# Altium schematic sheet Sheet1.SchDoc
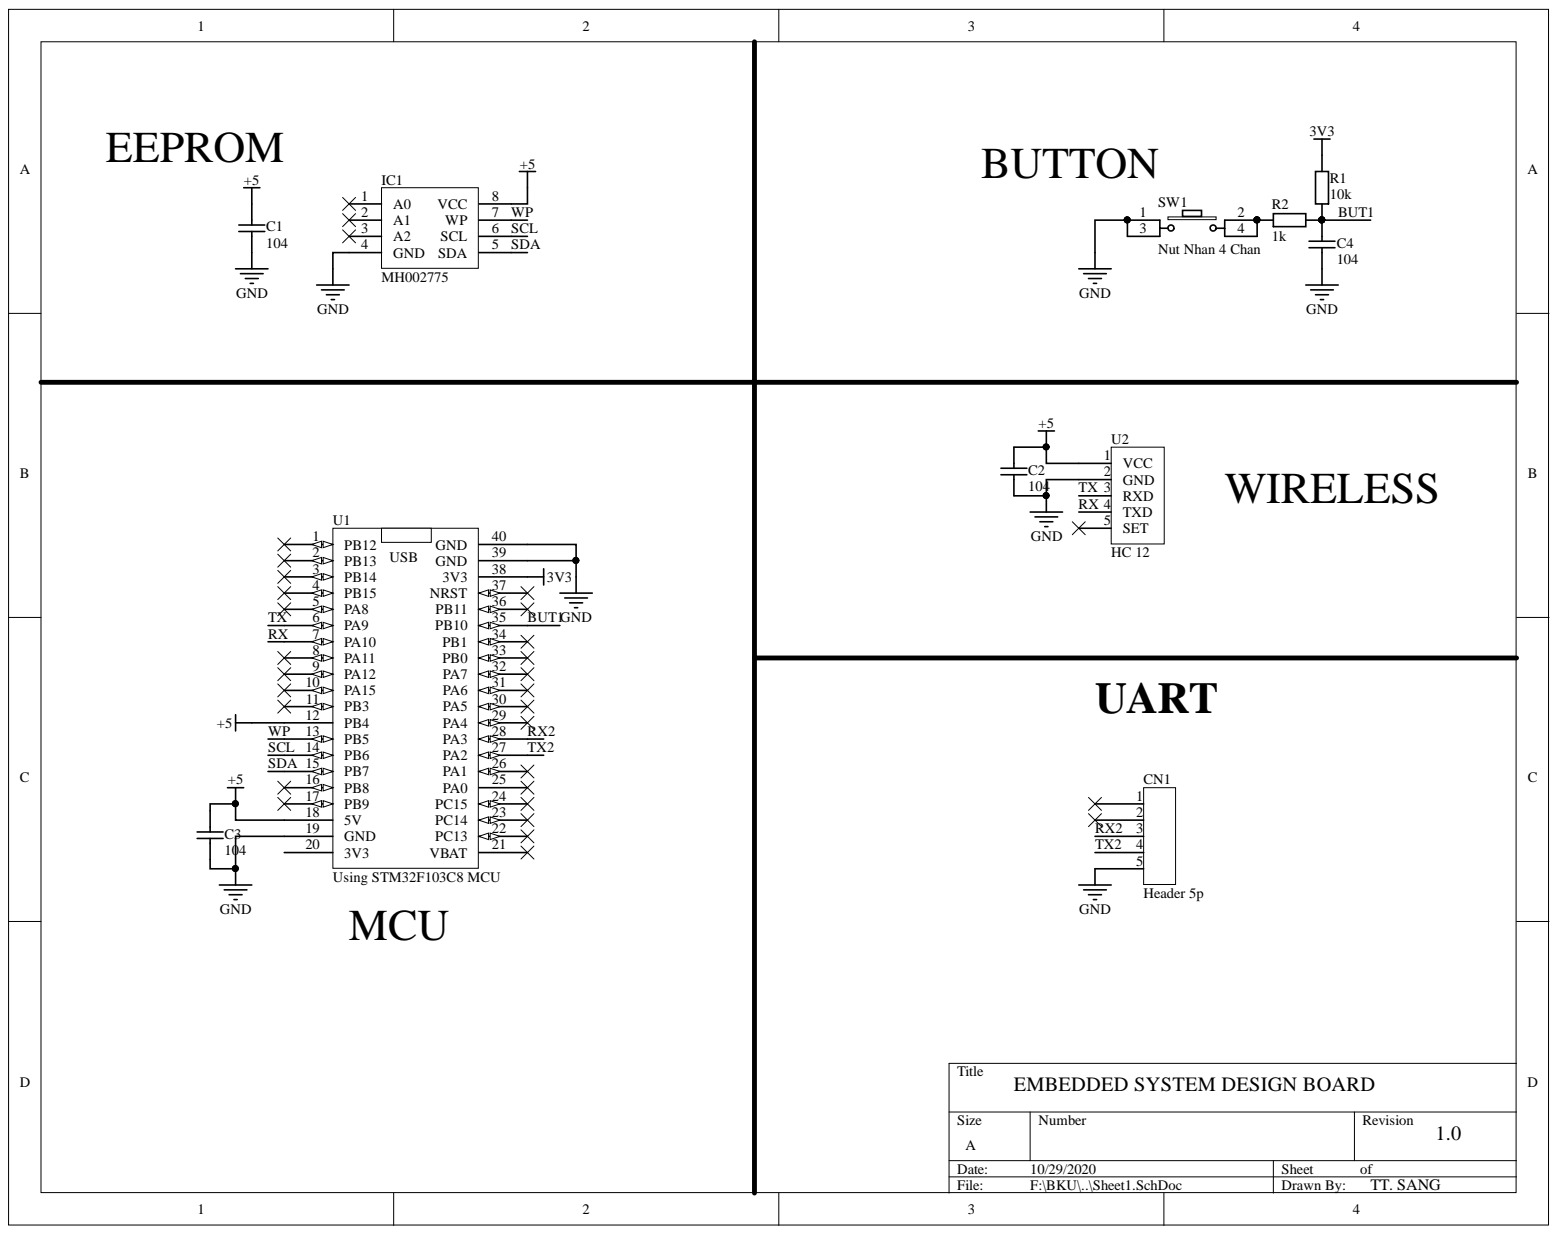
[diagram p0: Sheet1.SchDoc]

## Parts
C1  104  [tu 0805]
C2  104  [tu 0805]
C3  104  [tu 0805]
C4  104  [tu 0805]
CN1  Header 5p  [HEADER 5P MALE]
IC1  MH002775  [DIP8]
R1  10k  [tro smd 0805]
R2  1k  [tro smd 0805]
SW1  Nut Nhan 4 Chan  [SW4]
U1  Using STM32F103C8 MCU  [STM32 BluePill]
U2  HC 12  [HC 12]

## Nets
3V3: R1.2 U1.38(3V3)
+5: C1.1 C2.1 C3.1 IC1.8(VCC) U1.12(PB4) U1.18(5V) U2.1(VCC)
GND: C1.2 C2.2 C3.2 C4.2 CN1.5 IC1.4(GND) SW1.1 SW1.3 U1.19(GND) U1.39(GND) U1.40(GND) U2.2(GND)
BUT1: C4.1 R1.1 R2.2 U1.35(PB10)
RX: U1.7(PA10) U2.4(TXD)
RX2: CN1.3 U1.28(PA3)
SCL: IC1.6(SCL) U1.14(PB6)
SDA: IC1.5(SDA) U1.15(PB7)
TX: U1.6(PA9) U2.3(RXD)
TX2: CN1.4 U1.27(PA2)
WP: IC1.7(WP) U1.13(PB5)
NetR2_1: R2.1 SW1.2 SW1.4

Not connected: CN1 1 2; IC1 1(A0) 2(A1) 3(A2); U1 1(PB12) 2(PB13) 3(PB14) 4(PB15) 5(PA8) 8(PA11) 9(PA12) 10(PA15) 11(PB3) 16(PB8) 17(PB9) 20(3V3) 21(VBAT) 22(PC13) 23(PC14) 24(PC15) 25(PA0) 26(PA1) 29(PA4) 30(PA5) 31(PA6) 32(PA7) 33(PB0) 34(PB1) 36(PB11) 37(NRST); U2 5(SET)

## Library data of the parts
C1-C4 = Tu 0805 104 50V 10%: Dán; Don_Vi: Con; Ma_Hang: MH004551; So_Chan: 2; Ten_Linh_Kien: Tụ 0805 104-100nF 10% 50V; Chung_Loai: Dán; Component Kind: Standard; Library Name: THU VIEN SCH.SchLib
IC1 = 24Cxx_MH: Dán; Don_Vi: Con; Ten_Linh_Kien: AT24C01 DIP8 EEPROM 1KBIT; Chung_Loai: Dán; Component Kind: Standard; Library Name: THU VIEN SCH.SchLib; Ma_Hang: MH002775
R1 = Tro 0805 1% 10k: Dán; Don_Vi: Con; Ma_Hang: MH005473; So_Chan: 2; Ten_Linh_Kien: Trở 0805 1% 10k; Chung_Loai: Dán; Component Kind: Standard; Library Name: THU VIEN SCH.SchLib
R2 = Tro 0805 1% 3.3k: Dán; Don_Vi: Con; Ma_Hang: MH005472; So_Chan: 2; Ten_Linh_Kien: Trở 0805 1% 3.3k; Chung_Loai: Dán; Component Kind: Standard; Library Name: THU VIEN SCH.SchLib
SW1 = Nut Nhan 4 Chan: Cắm; Don_Vi: Con; Ma_Hang: MH004077; Value: Nút Nhấn 4 Chân 6x6x9MM DIP; Component Kind: Standard; Library Name: THU VIEN SCH.SchLib
U1 = BluePill: Component Kind: Standard; Description: Using STM32F103C8 MCU; Library Name: My_Lib.SchLib
CN1 = Header 5p: Component Kind: Standard; Library Name: My_Lib.SchLib
U2 = HC 12: Component Kind: Standard; Library Name: My_Lib.SchLib
